# Altium schematic sheet uController.SchDoc
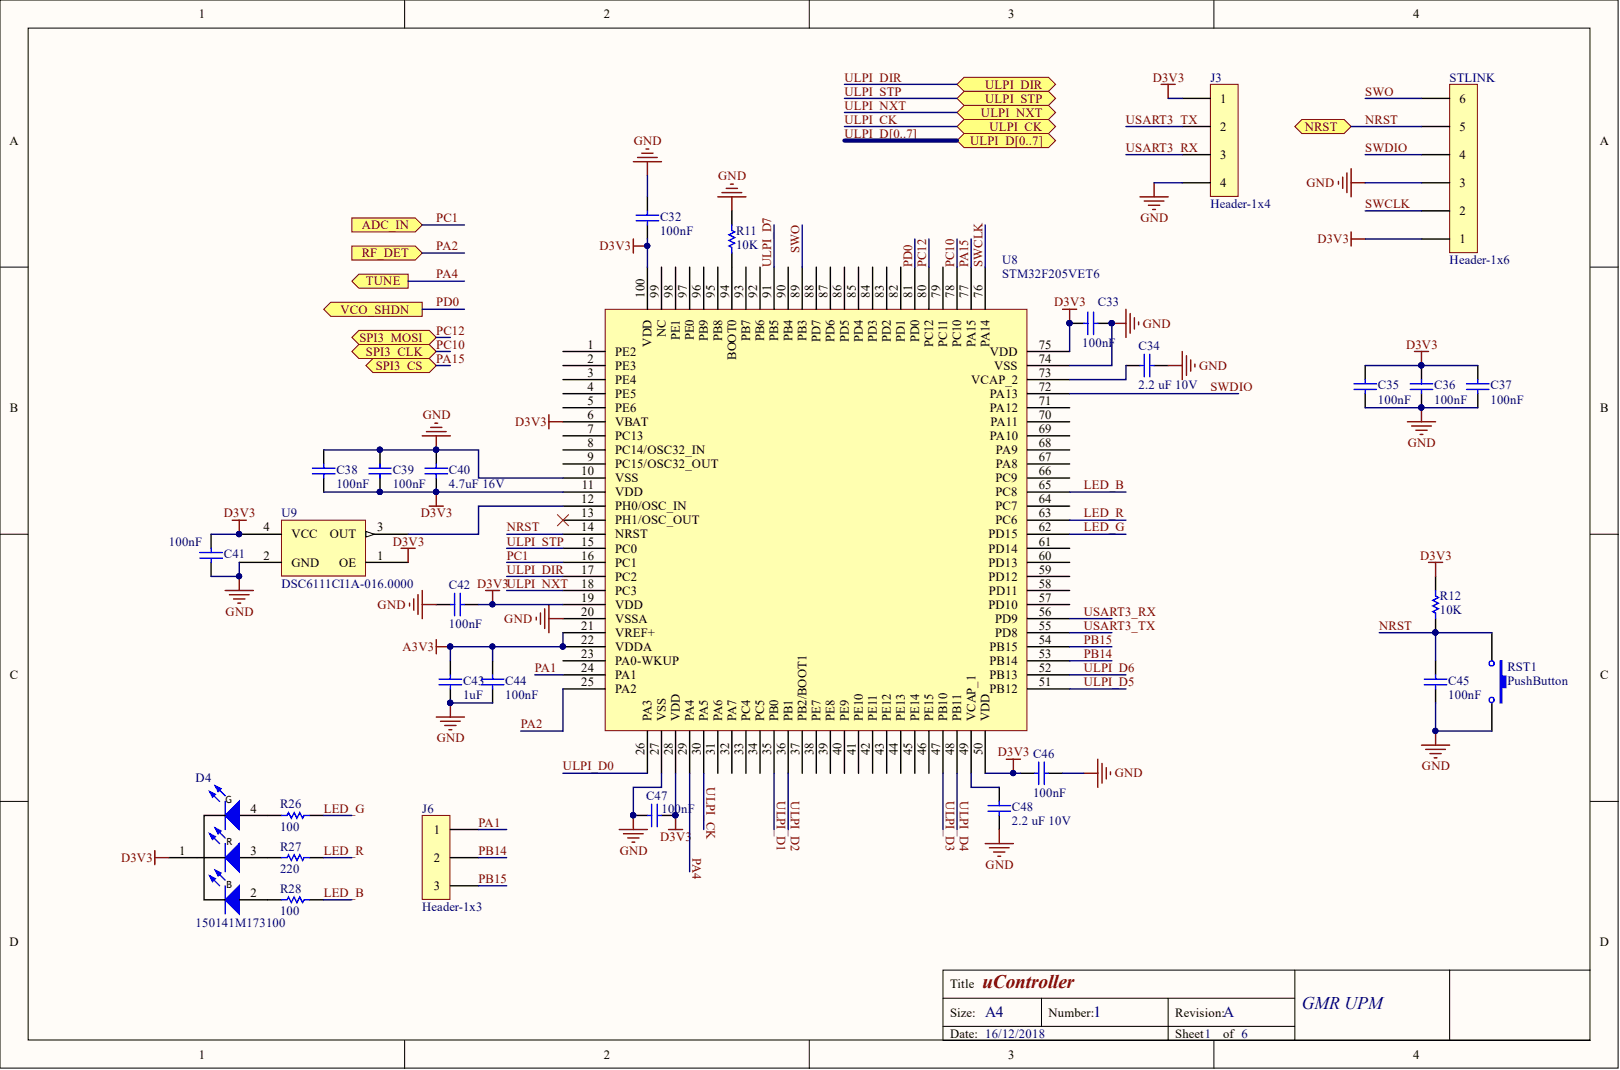
[diagram p0: uController.SchDoc]

## Parts
C32  100nF  [0603 Cap]
C33  100nF  [0603 Cap]
C34  2.2 uF 10V  Voltage - Rated: 10V  [0603 Cap]
C35  100nF  [0603 Cap]
C36  100nF  [0603 Cap]
C37  100nF  [0603 Cap]
C38  100nF  [0603 Cap]
C39  100nF  [0603 Cap]
C40  4.7uF 16V  [0805 CAP]
C41  100nF  [0603 Cap]
C42  100nF  [0603 Cap]
C43  1uF  [0603 Cap]
C44  100nF  [0603 Cap]
C45  100nF  [0603 Cap]
C46  100nF  [0603 Cap]
C47  100nF  [0603 Cap]
C48  2.2 uF 10V  [0603 Cap]
D4  150141M173100  [DFN270P320X210-4N]
J3  Header-1x4  [HEADER-1X4]
J6  Header-1x3  [Header-1x3-Jumper]
R11  10K  [0603 Res]
R12  10K  [0603 Res]
R26  100  [RESC1005X04L]
R27  220  [RESC1005X04L]
R28  100  [RESC1005X04L]
RST1  PushButton  [PushButton]
STLINK  Header-1x6  [Header-1x6]
U8  STM32F205VET6  [LQFP-100]
U9  DSC6111CI1A-016.0000  [DFN 3.2x2.5]

## Ports
ADC_IN, NRST, RF_DET, SPI3_CLK, SPI3_CS, SPI3_MOSI, TUNE, ULPI_CK, ULPI_D0, ULPI_D1, ULPI_D2, ULPI_D3, ULPI_D4, ULPI_D5, ULPI_D6, ULPI_D7, ULPI_D[0..7], ULPI_DIR, ULPI_NXT, ULPI_STP, VCO_SHDN

## Nets
A3V3: C43.2 C44.1 U8.21(VREF+) U8.22(VDDA)
D3V3: C32.2 C33.1 C35.2 C36.2 C37.2 C38.1 C39.1 C40.1 C41.2 C42.1 C46.2 C47.1 D4.1 J3.1 R12.2 STLINK.1 U8.6(VBAT) U8.11(VDD) U8.19(VDD) U8.28(VDD) U8.50(VDD) U8.75(VDD) U8.100(VDD) U9.1(OE) U9.4(VCC)
GND: C32.1 C33.2 C34.1 C35.1 C36.1 C37.1 C38.2 C39.2 C40.2 C41.1 C42.2 C43.1 C44.2 C45.1 C46.1 C47.2 C48.1 J3.4 R11.2 RST1.2 STLINK.3 U8.10(VSS) U8.20(VSSA) U8.27(VSS) U8.74(VSS) U9.2(GND)
LED_B: R28.2 U8.65(PC8)
LED_G: R26.2 U8.62(PD15)
LED_R: R27.2 U8.63(PC6)
NRST: C45.2 R12.1 RST1.1 STLINK.5 U8.14(NRST) ; port NRST
PA1: J6.1 U8.24(PA1)
PA2: U8.25(PA2) ; port RF_DET
PA4: U8.29(PA4) ; port TUNE
PA15: U8.77(PA15) ; port SPI3_CS
PB14: J6.2 U8.53(PB14)
PB15: J6.3 U8.54(PB15)
PC1: U8.16(PC1) ; port ADC_IN
PC10: U8.78(PC10) ; port SPI3_CLK
PC12: U8.80(PC12) ; port SPI3_MOSI
PD0: U8.81(PD0) ; port VCO_SHDN
SWCLK: STLINK.2 U8.76(PA14)
SWDIO: STLINK.4 U8.72(PA13)
SWO: STLINK.6 U8.89(PB3)
ULPI_CK: U8.30(PA5) ; port ULPI_CK
ULPI_D0: U8.26(PA3) ; port ULPI_D[0..7]  [also labelled ULPI_D]
ULPI_D1: U8.35(PB0) ; port ULPI_D[0..7]  [also labelled ULPI_D]
ULPI_D2: U8.36(PB1) ; port ULPI_D[0..7]  [also labelled ULPI_D]
ULPI_D3: U8.47(PB10) ; port ULPI_D[0..7]  [also labelled ULPI_D]
ULPI_D4: U8.48(PB11) ; port ULPI_D[0..7]  [also labelled ULPI_D]
ULPI_D5: U8.51(PB12) ; port ULPI_D[0..7]  [also labelled ULPI_D]
ULPI_D6: U8.52(PB13) ; port ULPI_D[0..7]  [also labelled ULPI_D]
ULPI_D7: U8.91(PB5) ; port ULPI_D[0..7]  [also labelled ULPI_D]
ULPI_DIR: U8.17(PC2) ; port ULPI_DIR
ULPI_NXT: U8.18(PC3) ; port ULPI_NXT
ULPI_STP: U8.15(PC0) ; port ULPI_STP
USART3_RX: J3.3 U8.56(PD9)
USART3_TX: J3.2 U8.55(PD8)
NetC34_2: C34.2 U8.73(VCAP_2)
NetC48_2: C48.2 U8.49(VCAP_1)
NetD4_2: D4.2 R28.1
NetD4_3: D4.3 R27.1
NetD4_4: D4.4 R26.1
NetR11_1: R11.1 U8.94(BOOT0)
NetU8_12: U8.12(PH0/OSC_IN) U9.3(OUT)

Not connected: U8 1(PE2) 2(PE3) 3(PE4) 4(PE5) 5(PE6) 7(PC13) 8(PC14/OSC32_IN) 9(PC15/OSC32_OUT) 13(PH1/OSC_OUT) 23(PA0-WKUP) 31(PA6) 32(PA7) 33(PC4) 34(PC5) 37(PB2/BOOT1) 38(PE7) 39(PE8) 40(PE9) 41(PE10) 42(PE11) 43(PE12) 44(PE13) 45(PE14) 46(PE15) 57(PD10) 58(PD11) 59(PD12) 60(PD13) 61(PD14) 64(PC7) 66(PC9) 67(PA8) 68(PA9) 69(PA10) 70(PA11) 71(PA12) 79(PC11) 82(PD1) 83(PD2) 84(PD3) 85(PD4) 86(PD5) 87(PD6) 88(PD7) 90(PB4) 92(PB6) 93(PB7) 95(PB8) 96(PB9) 97(PE0) 98(PE1) 99(NC)

## Library data of the parts
C32 C33 C35-C39 C41 C42 C44-C47 = C0603C104K4RACTU: CAP CER 0.1UF 16V X7R 0603; Manufacturer: KEMET; Capacitance: 0.1µF; Tolerance: ±10%; Applications: General Purpose; Category: Capacitors; Component Kind: Standard; ComponentLink1Description: http://www.kemet.com/docfinder?Partnumber=C0603C104K4RACTU; ComponentLink1URL: http://www.kemet.com/docfinder?Partnumber=C0603C104K4RACTU; Failure Rate: -; Features: -; Height - Seated (Max): -; Lead Spacing: -; Lead Style: -; Library Name: Componentes_base.SchLib; Manufacturer Part Number: C0603C104K4RACTU; Mounting Type: Surface Mount, MLCC; Operating Temperature: -55°C ~ 125°C; Package / Case: 0603 (1608 Metric); Packaging: Digi-Reel®; Part Status: Active; Pricing 1: 1=0.09, 10=0.022, 25=0.016, 50=0.0122, 100=0.01, 250=0.00836, 500=0.0071, 1000=0.00559 (EUR); Ratings: -; RoHS: RoHS Compliant; Size / Dimension: 0.063" L x 0.031" W (1.60mm x 0.80mm); Stock 1: 4491346; Supplier 1: Digi-Key; Supplier Part Number 1: 399-1096-6-ND; Temperature Coefficient: X7R; Thickness (Max): 0.034" (0.87mm); Voltage - Rated: 16V
C34 C48 = CL10B225KP8NNNC: CAP CER 2.2UF 10V X7R 0603; Manufacturer: Samsung Electro-Mechanics America, Inc.; Capacitance: 2.2µF; Tolerance: ±10%; Applications: General Purpose; Category: Capacitors; Component Kind: Standard; ComponentLink1Description: http://www.samsungsem.com/kr/support/product-search/mlcc/__icsFiles/afieldfile/2016/08/18/S_CL10B225KP8NNNC.pdf; ComponentLink1URL: http://www.samsungsem.com/kr/support/product-search/mlcc/__icsFiles/afieldfile/2016/08/18/S_CL10B225KP8NNNC.pdf; Features: -; Height - Seated (Max): -; Lead Spacing: -; Lead Style: -; Library Name: Componentes_base.SchLib; Manufacturer Part Number: CL10B225KP8NNNC; Mounting Type: Surface Mount, MLCC; Operating Temperature: -55°C ~ 125°C; Package / Case: 0603 (1608 Metric); Packaging: Digi-Reel®; Part Status: Active; Pricing 1: 1=0.15, 10=0.105, 25=0.0764, 50=0.0592, 100=0.0491, 250=0.04068, 500=0.03444, 1000=0.02851 (EUR); Ratings: -; RoHS: RoHS Compliant; Size / Dimension: 0.063" L x 0.031" W (1.60mm x 0.80mm); Stock 1: 226342; Supplier 1: Digi-Key; Supplier Part Number 1: 1276-1134-6-ND; Temperature Coefficient: X7R; Thickness (Max): 0.035" (0.90mm)
  C48: Voltage - Rated: 10V
C40 = GRM21BR71C475KE51L: CAP CER 4.7UF 16V X7R 0805; Manufacturer: Murata Electronics North America; Capacitance: 4.7µF; Tolerance: ±10%; Applications: General Purpose; Category: Capacitors; Component Kind: Standard; Failure Rate: -; Features: -; Height - Seated (Max): -; Lead Spacing: -; Lead Style: -; Library Name: Componentes_base.SchLib; Manufacturer Part Number: GRM21BR71C475KE51L; Mounting Type: Surface Mount, MLCC; Operating Temperature: -55°C ~ 125°C; Package / Case: 0805 (2012 Metric); Part Status: Active; Pricing 1: 1=0.16, 10=0.111, 25=0.0816, 50=0.0666, 100=0.0562, 250=0.04588, 500=0.03848, 1000=0.03403 (EUR); Ratings: -; RoHS: RoHS Compliant; Size / Dimension: 0.079" L x 0.049" W (2.00mm x 1.25mm); Stock 1: 5810; Supplier 1: Digi-Key; Supplier Part Number 1: 490-14466-6-ND; Temperature Coefficient: X7R; Thickness (Max): 0.055" (1.40mm); Voltage - Rated: 16V
C43 = CL10B105MO8NNWC: CAP CER 1UF 16V X7R 0603; Manufacturer: Samsung Electro-Mechanics America, Inc.; Capacitance: 1µF; Tolerance: ±20%; Applications: General Purpose; Category: Capacitors; Component Kind: Standard; ComponentLink1Description: http://www.samsungsem.com/kr/support/library/product-catalog/__icsFiles/afieldfile/2016/09/27/MLCC.pdf; ComponentLink1URL: http://www.samsungsem.com/kr/support/library/product-catalog/__icsFiles/afieldfile/2016/09/27/MLCC.pdf; Features: -; Height - Seated (Max): -; Lead Spacing: -; Lead Style: -; Library Name: Componentes_base.SchLib; Manufacturer Part Number: CL10B105MO8NNWC; Mounting Type: Surface Mount, MLCC; Operating Temperature: -55°C ~ 125°C; Package / Case: 0603 (1608 Metric); Packaging: Digi-Reel®; Part Status: Active; Pricing 1: 1=0.09, 10=0.02, 25=0.0144, 50=0.0108, 100=0.0089, 250=0.00744, 500=0.00636, 1000=0.00499 (EUR); Ratings: -; RoHS: RoHS Compliant; Size / Dimension: 0.063" L x 0.031" W (1.60mm x 0.80mm); Stock 1: 77746; Supplier 1: Digi-Key; Supplier Part Number 1: 1276-6524-6-ND; Temperature Coefficient: X7R; Thickness (Max): 0.035" (0.90mm); Voltage - Rated: 16V
D4 = 150141M173100: LED RGB CLEAR 1411 SMD; Manufacturer: Wurth Electronics Inc.; Color: Red, Green, Blue (RGB); Configuration: Common Anode; Current - Test: 20mA Red, 20mA Green, 20mA Blue; Lens Color: Colorless; Lens Style/Size: Round with Flat Top, 2.40mm; Millicandela Rating: 270mcd Red, 950mcd Green, 230mcd Blue; Viewing Angle: 120°; Voltage - Forward (Vf) (Typ): 2V Red, 3.2V Green, 3.2V Blue; Wavelength - Dominant: 625nm Red, 520nm Green, 470nm Blue; Wavelength - Peak: 635nm Red, 515nm Green, 465nm Blue; Category: Optoelectronics; Component Kind: Standard; ComponentLink1Description: http://katalog.we-online.de/led/datasheet/150141M173100.pdf; ComponentLink1URL: http://katalog.we-online.de/led/datasheet/150141M173100.pdf; Features: -; Height (Max): 2.10mm; Lens Transparency: Clear; Library Name: Componentes_base.SchLib; Manufacturer Part Number: 150141M173100; Mounting Type: Surface Mount; Package / Case: 1411 (3528 Metric); Packaging: Cut Tape (CT); Part Status: Active; Pricing 1: 1=0.4, 100=0.3462, 500=0.2867 (EUR); RoHS: RoHS Compliant; Size / Dimension: 3.50mm L x 2.80mm W; Stock 1: 7809; Supplier 1: Digi-Key; Supplier Device Package: 3528; Supplier Part Number 1: 732-4998-1-ND
R11 R12 = RC0603FR-0710KL: RES SMD 10K OHM 1% 1/10W 0603; Manufacturer: Yageo; Power (Watts): 0.1W, 1/10W; Temperature Coefficient: ±100ppm/°C; Category: Resistors; Component Kind: Standard; ComponentLink1Description: http://www.yageo.com/documents/recent/PYu-RC_Group_51_RoHS_L_7.pdf; ComponentLink1URL: http://www.yageo.com/documents/recent/PYu-RC_Group_51_RoHS_L_7.pdf; Composition: Thick Film; Failure Rate: -; Features: Moisture Resistant; Height - Seated (Max): 0.022" (0.55mm); Library Name: Componentes_base.SchLib; Manufacturer Part Number: RC0603FR-0710KL; Operating Temperature: -55°C ~ 155°C; Package / Case: 0603 (1608 Metric); Packaging: Cut Tape (CT); Part Status: Active; Pricing 1: 1=0.09, 10=0.012, 25=0.0088, 50=0.0066, 100=0.0049, 250=0.00372, 500=0.00296, 1000=0.00218 (EUR); Resistance (Ohms): 10k; RoHS: RoHS Compliant; Size / Dimension: 0.063" L x 0.031" W (1.60mm x 0.80mm); Stock 1: 17023109; Supplier 1: Digi-Key; Supplier Device Package: 0603; Supplier Part Number 1: 311-10.0KHRCT-ND; Tolerance: ±1%
R26 R28 = MCR01MRTF1000: RES 100 OHM 1/16W 1% 0402 SMD; Manufacturer: Rohm Semiconductor; Power (Watts): 0.063W, 1/16W; Temperature Coefficient: ±100ppm/°C; Category: Resistors; Component Kind: Standard; ComponentLink1Description: http://rohmfs.rohm.com/en/products/databook/datasheet/passive/resistor/chip_resistor/mcr_g.pdf; ComponentLink1URL: http://rohmfs.rohm.com/en/products/databook/datasheet/passive/resistor/chip_resistor/mcr_g.pdf; Composition: Thick Film; Features: -; Height: 0.016" (0.40mm); Library Name: Componentes_base.SchLib; Manufacturer Part Number: MCR01MRTF1000; Number of Terminations: 2; Operating Temperature: -55°C ~ 155°C; Package / Case: 0402 (1005 Metric); Packaging: Cut Tape (CT); Pricing 1: 1=0.09, 10=0.011, 25=0.008, 100=0.0046, 250=0.00348, 500=0.00278, 1000=0.00205, 5000=0.00147 (EUR); Resistance (Ohms): 100; RoHS: RoHS Compliant; Size / Dimension: 0.039" L x 0.020" W (1.00mm x 0.50mm); Stock 1: 128227; Supplier 1: Digi-Key; Supplier Device Package: 0402 (1005 Metric); Supplier Part Number 1: RHM100CDCT-ND; Tolerance: ±1%
R27 = RC0402FR-07220RL: RES SMD 220 OHM 1% 1/16W 0402; Manufacturer: Yageo; Power (Watts): 0.063W, 1/16W; Temperature Coefficient: ±100ppm/°C; Category: Resistors; Component Kind: Standard; ComponentLink1Description: http://www.yageo.com/NewPortal/yageodocoutput?fileName=/pdf/R-Chip/PYu-RC_Group_51_RoHS_L_4.pdf; ComponentLink1URL: http://www.yageo.com/NewPortal/yageodocoutput?fileName=/pdf/R-Chip/PYu-RC_Group_51_RoHS_L_4.pdf; Composition: Thick Film; Failure Rate: -; Features: Moisture Resistant; Height - Seated (Max): 0.016" (0.40mm); Library Name: Componentes_base.SchLib; Manufacturer Part Number: RC0402FR-07220RL; Number of Terminations: 2; Operating Temperature: -55°C ~ 155°C; Package / Case: 0402 (1005 Metric); Packaging: Cut Tape (CT); Part Status: Active; Pricing 1: 1=0.09, 10=0.011, 25=0.0084, 50=0.0062, 100=0.0046, 250=0.00348, 500=0.00278, 1000=0.00205, 5000=0.00147 (EUR); Resistance (Ohms): 220; RoHS: RoHS Compliant; Size / Dimension: 0.039" L x 0.020" W (1.00mm x 0.50mm); Stock 1: 624682; Supplier 1: Digi-Key; Supplier Device Package: 0402; Supplier Part Number 1: 311-220LRCT-ND; Tolerance: ±1%
U8 = STM32F205VET6: IC MCU 32BIT 512KB FLASH 100LQFP; Manufacturer: STMicroelectronics; Connectivity: CAN, I²C, IrDA, LIN, MMC, SPI, UART/USART, USB OTG; Core Processor: ARM® Cortex®-M3; Core Size: 32-Bit; Data Converters: A/D 16x12b; D/A 2x12b; Number of I/O: 82; Oscillator Type: Internal; Peripherals: Brown-out Detect/Reset, DMA, I²S, LCD, POR, PWM, WDT; Program Memory Size: 512KB (512K x 8); RAM Size: 132K x 8; Speed: 120MHz; Voltage - Supply (Vcc/Vdd): 1.8 V ~ 3.6 V; Category: Integrated Circuits (ICs); Component Kind: Standard; ComponentLink1Description: http://media.digikey.com/pdf/Data%20Sheets/ST%20Microelectronics%20PDFS/STM32F20(5,7)xx.pdf; ComponentLink1URL: http://media.digikey.com/pdf/Data%20Sheets/ST%20Microelectronics%20PDFS/STM32F20(5,7)xx.pdf; EEPROM Size: -; Library Name: Componentes_base.SchLib; Manufacturer Part Number: STM32F205VET6; Operating Temperature: -40°C ~ 85°C (TA); Package / Case: 100-LQFP; Packaging: Tray; Part Status: Active; Pricing 1: 1=9.78, 10=8.83, 100=7.3108, 500=6.36612, 1000=5.54468 (EUR); Program Memory Type: FLASH; RoHS: RoHS Compliant; Stock 1: 1188; Supplier 1: Digi-Key; Supplier Device Package: 100-LQFP (14x14); Supplier Part Number 1: 497-11160-ND
U9 = DSC6111CI1A-016.0000: ULTRA-LOW POWER,MEMS OSCILLATOR,; Manufacturer: Microchip Technology; Current - Supply (Disable) (Max): 10.5µA; Current - Supply (Max): 3mA (Typ); Frequency: 16MHz; Frequency Stability: ±25ppm; Function: Standby (Power Down); Output: CMOS; Voltage - Supply: 1.71 V ~ 3.63 V; Category: Crystals, Oscillators, Resonators; Component Kind: Standard; ComponentLink1Description: http://www.microchip.com/mymicrochip/filehandler.aspx?ddocname=en587492; ComponentLink1URL: http://www.microchip.com/mymicrochip/filehandler.aspx?ddocname=en587492; Height - Seated (Max): 0.035" (0.90mm); Library Name: Componentes_base.SchLib; Manufacturer Part Number: DSC6111CI1A-016.0000; Mounting Type: Surface Mount; Operating Temperature: -40°C ~ 85°C; Package / Case: 4-SMD, No Lead; Packaging: Tube; Part Status: Active; Pricing 1: 1=0.81, 25=0.6744, 100=0.6514 (EUR); Ratings: AEC-Q100; RoHS: RoHS Compliant; Size / Dimension: 0.126" L x 0.098" W (3.20mm x 2.50mm); Stock 1: 957; Supplier 1: Digi-Key; Supplier Part Number 1: DSC6111CI1A-016.0000-ND; Type: MEMS (Silicon)
J3 = Header-1x4: Component Kind: Standard; Library Name: B105connectors.SchLib
J6 = Header-1x3: Component Kind: Standard; Library Name: B105lib.IntLib
RST1 = PushButton: Component Kind: Standard; Library Name: B105lib.IntLib
STLINK = Header-1x6: Component Kind: Standard; Library Name: B105connectors.SchLib
